# Altium schematic sheet HELPME.SchDoc
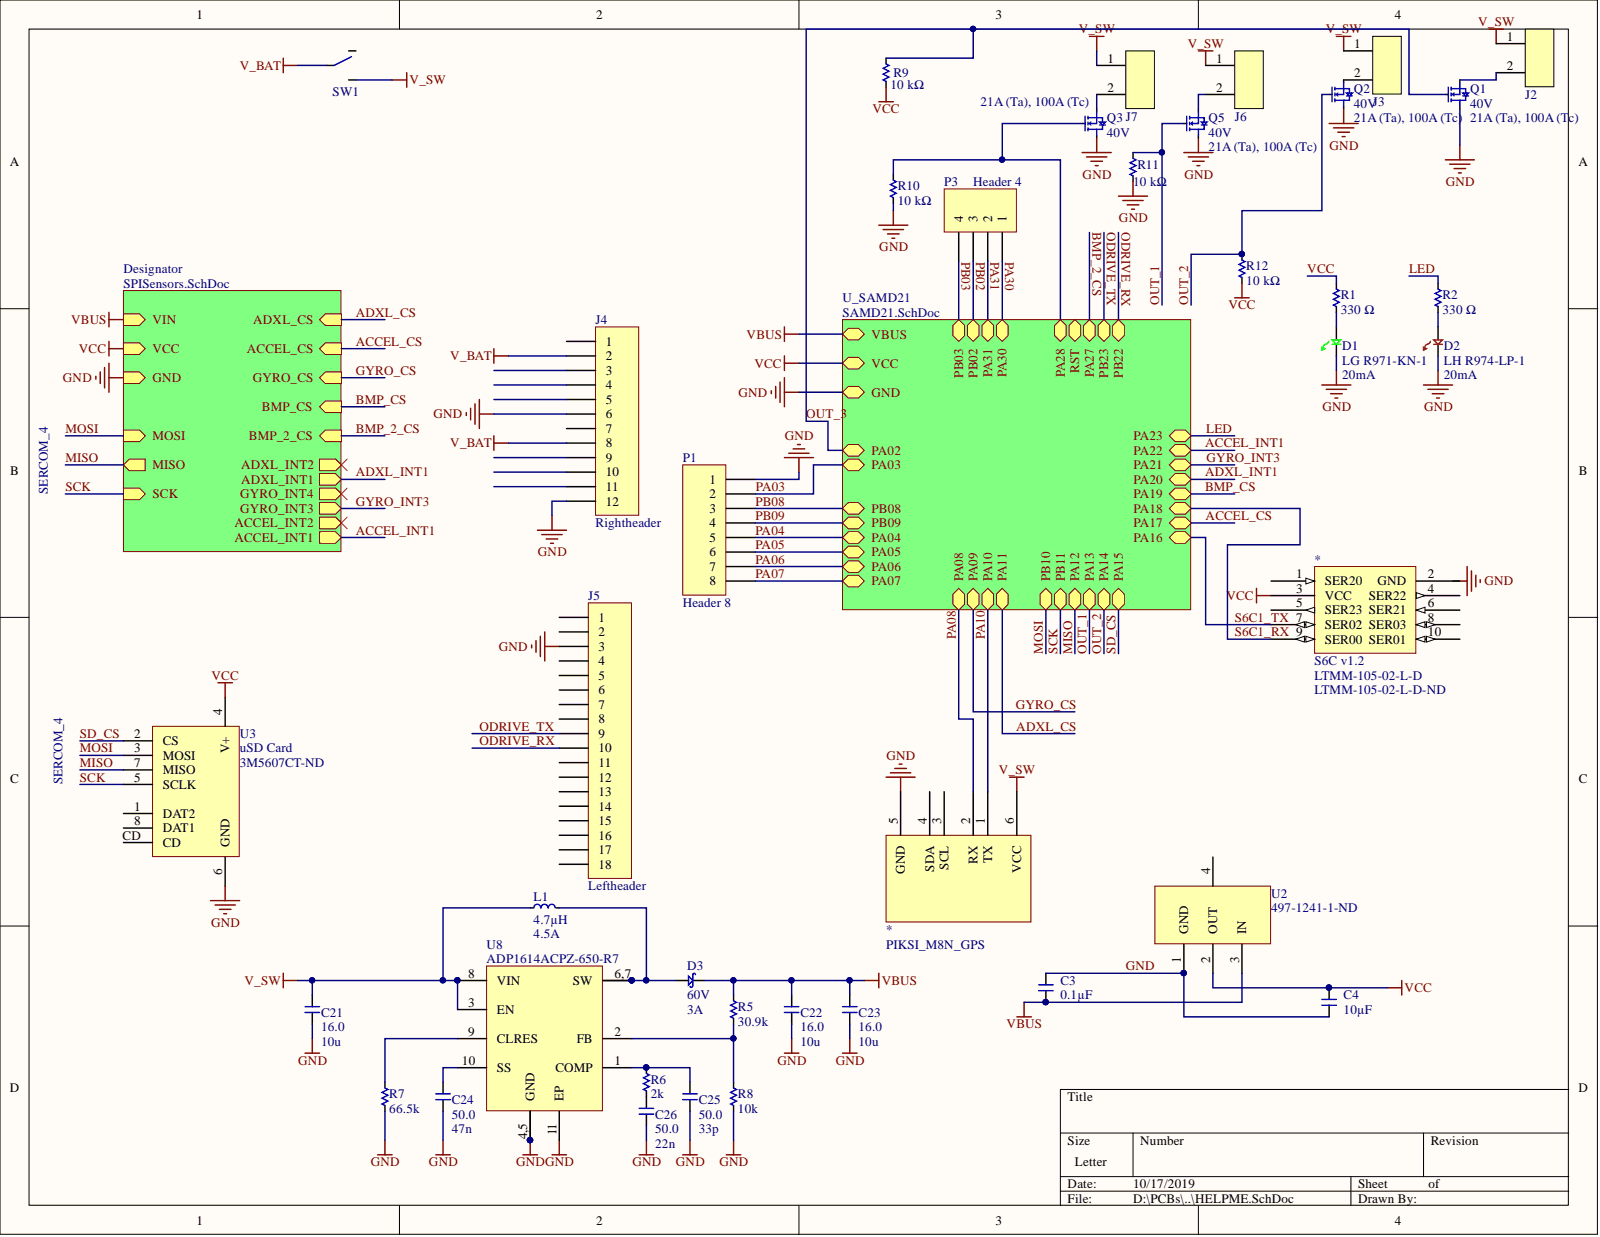
[diagram p0: HELPME.SchDoc]

## Parts
*  S6C v1.2  Supplier Part Number 1: LTMM-105-02-L-D  [S6C]
*  PIKSI_M8N_GPS  [PIKSI_M8N_GPS]
C3  Capacitance (shorthand): 0.1µF  [C0603]  (hidden comment: __template_cap)
C4  Capacitance (shorthand): 10µF  [C0603]  (hidden comment: __template_cap)
C21  human_value: 10u  voltage: 16.0  [C0805]  (hidden comment: __template_cap)
C22  human_value: 10u  voltage: 16.0  [C0805]  (hidden comment: __template_cap)
C23  human_value: 10u  voltage: 16.0  [C0805]  (hidden comment: __template_cap)
C24  voltage: 50.0  [C0805]  (hidden comment: __template_cap)
C25  human_value: 33p  voltage: 50.0  [C0805]  (hidden comment: __template_cap)
C26  human_value: 22n  voltage: 50.0  [C0805]  (hidden comment: __template_cap)
D1  Current - Test: 20mA  [0805_Diode]  (hidden comment: LED_LY971)
D2  Current - Test: 20mA  Manufacturer Part Number: LH R974-LP-1  [0805_Diode]  (hidden comment: LED_LY974)
D3  Current - Average Rectified (Io): 3A  Voltage - DC Reverse (Vr) (Max): 60V  [SMA]  (hidden comment: SCHOTTKY_B360A-13-F)
J2  CONN_BARRIER_STRIP_2POS  [TE_BARRIER_STRIP_2POS]  (value not drawn)
J3  CONN_BARRIER_STRIP_2POS  [TE_BARRIER_STRIP_2POS]  (value not drawn)
J4  Rightheader  [HDR1X12]
J5  Leftheader  [HDR1X18]
J6  CONN_BARRIER_STRIP_2POS  [TE_BARRIER_STRIP_2POS]  (value not drawn)
J7  CONN_BARRIER_STRIP_2POS  [TE_BARRIER_STRIP_2POS]  (value not drawn)
L1  Current Rating: 4.5A  Inductance: 4.7µH  [SMD5230]  (hidden comment: IND_IHLP2020CZER4R7M11)
P1  Header 8  [HDR1X8]
P3  Header 4  [HDR1X4]
Q1  Drain to Source Voltage (Vdss): 40V  [8PTDFN]  (hidden comment: NFET_BSC035N04LSG)
Q2  Drain to Source Voltage (Vdss): 40V  [8PTDFN]  (hidden comment: NFET_BSC035N04LSG)
Q3  Drain to Source Voltage (Vdss): 40V  [8PTDFN]  (hidden comment: NFET_BSC035N04LSG)
Q5  Drain to Source Voltage (Vdss): 40V  [8PTDFN]  (hidden comment: NFET_BSC035N04LSG)
R1  Resistance: 330 Ω  [R0603]  (hidden comment: __template_resistor)
R2  Resistance: 330 Ω  [R0603]  (hidden comment: __template_resistor)
R5  value 30.9k  [R0603]  (hidden comment: __template_resistor)
R6  value 2k  [R0603]  (hidden comment: __template_resistor)
R7  value 66.5k  [R0603]  (hidden comment: __template_resistor)
R8  value 10k  [R0603]  (hidden comment: __template_resistor)
R9  Resistance: 10 kΩ  [R0805]  (hidden comment: __template_resistor)
R10  Resistance: 10 kΩ  [R0805]  (hidden comment: __template_resistor)
R11  Resistance: 10 kΩ  [R0805]  (hidden comment: __template_resistor)
R12  Resistance: 10 kΩ  [R0805]  (hidden comment: __template_resistor)
SW1  SWITCH_500SSP1S1M6QEA  [SWITCH_500SSP1S1M6QEA]  (value not drawn)
U2  Supplier Part Number 1: 497-1241-1-ND  [4SOT223]  (hidden comment: LDO_LD1117S33CTR)
U3  uSD Card  Supplier Part Number 1: 3M5607CT-ND  [MICROSD_CARD_SOCKET]
U8  Manufacturer Part Number: ADP1614ACPZ-650-R7  [10DFN3X3]  (hidden comment: BOOST_ADP1614_650_R7)

## Nets
V_BAT: J4.2 J4.8 SW1.2
V_SW: *.6 C21.1 J2.1 J3.1 J6.1 J7.1 L1.1 SW1.1 U8.3(EN) U8.8(VIN)
VBUS: C3.2 C22.1 C23.1 D3.2 R5.2 U2.3(IN)
GND: *.5 C3.1 C4.2 C21.2 C22.2 C23.2 C24.2 C25.2 C26.2 D1.1 D2.1 J4.6 J4.12 J5.3 P1.1 Q1.2 Q2.2 Q3.2 Q5.2 R7.1 R8.1 R10.2 R11.2 U2.1(GND) U3.6(GND) U8.4,5(GND) U8.5 U8.11(EP)
LED: R2.2
MISO: U3.7(MISO)
MOSI: U3.3(MOSI)
ODRIVE_RX: J5.10
ODRIVE_TX: J5.9
OUT_1: Q5.1 R11.1
OUT_2: Q2.1 R12.2
OUT_3: Q1.1 R9.2
PA03: P1.2
PA04: P1.5
PA05: P1.6
PA06: P1.7
PA07: P1.8
PA08: *.2
PA10: *.1
PA30: P3.1
PA31: P3.2
PB02: P3.3
PB03: P3.4
PB08: P1.3
PB09: P1.4
S6C1_RX: *.9(SER00)
S6C1_TX: *.7(SER02)
SCK: U3.5(SCLK)
SD_CS: U3.2(CS)
VCC: *.3 C4.1 R1.2 R9.1 R12.1 U2.2(OUT) U3.4(V+)
Net*_1: *.1
Net*_2: *.2
Net*_3: *.3
Net*_4: *.4
Net*_5: *.5
Net*_6: *.6
Net*_8: *.8(SER03)
Net*_10: *.10(SER01)
NetC24_1: C24.1 U8.10(SS)
NetC25_1: C25.1 R6.2 U8.1(COMP)
NetC26_1: C26.1 R6.1
NetD1_2: D1.2 R1.1
NetD2_2: D2.2 R2.1
NetD3_1: D3.1 L1.2 U8.6,7(SW) U8.7
NetJ2_2: J2.2 Q1.3
NetJ3_2: J3.2 Q2.3
NetJ4_1: J4.1
NetJ4_3: J4.3
NetJ4_4: J4.4
NetJ4_5: J4.5
NetJ4_7: J4.7
NetJ4_9: J4.9
NetJ4_10: J4.10
NetJ4_11: J4.11
NetJ5_1: J5.1
NetJ5_2: J5.2
NetJ5_4: J5.4
NetJ5_5: J5.5
NetJ5_6: J5.6
NetJ5_7: J5.7
NetJ5_8: J5.8
NetJ5_11: J5.11
NetJ5_12: J5.12
NetJ5_13: J5.13
NetJ5_14: J5.14
NetJ5_15: J5.15
NetJ5_16: J5.16
NetJ5_17: J5.17
NetJ5_18: J5.18
NetJ6_2: J6.2 Q5.3
NetJ7_2: J7.2 Q3.3
NetQ3_1: Q3.1 R10.1
NetR5_1: R5.1 R8.2 U8.2(FB)
NetR7_2: R7.2 U8.9(CLRES)
NetSW1_3: SW1.3
NetU2_4: U2.4
NetU3_1: U3.1(DAT2)
NetU3_8: U3.8(DAT1)
NetU3_CD: U3.CD
ACCEL_CS:
ACCEL_INT1:
ACCEL_INT2:
ADXL_CS:
ADXL_INT1:
ADXL_INT2:
BMP_2_CS:
BMP_CS:
GYRO_CS:
GYRO_INT3:
GYRO_INT4:
RST:

## Library data of the parts
C3 = CAP CER 0603 0.1µF X7R 50V 10%: Manufacturer: Murata Electronics North America; Capacitance - pF (Machine readable): 100000; Database Table Name: C0603$; Dielectric: X7R; type: capacitor; Component Kind: Standard; Library Name: passives.DbLib; Signal Integrity: Guessed Model; Supplier 1: Digikey; Supplier Part Number 1: 490-1519-1-ND
C4 = CAP CER 10UF 35V X5R 0603 20%: Manufacturer: Murata Electronics North America; Capacitance - pF (Machine readable): 10000000; Database Table Name: C0603$; Dielectric: X5R; type: capacitor; Component Kind: Standard; Library Name: passives.DbLib; Signal Integrity: Guessed Model; Supplier 1: Digikey; Supplier Part Number 1: 490-13248-1-ND
C21-C23 = 587-1295-1-ND: Database Table Name: capacitor$; tolerance: 10%; type: capacitor; value: 1e-05; Component Kind: Standard; package: 0805; price: 0.315; Supplier Part Number 1: 587-1295-1-ND; Library Name: sscp.DbLib
C24 = 478-1391-1-ND: Database Table Name: capacitor$; human_value: 47n; tolerance: 10%; type: capacitor; value: 4.7e-08; Component Kind: Standard; package: 0805; price: 0.2; Supplier Part Number 1: 478-1391-1-ND; Library Name: sscp.DbLib
C25 = 399-1115-1-ND: Database Table Name: capacitor$; tolerance: 5%; type: capacitor; value: 3.3e-11; Component Kind: Standard; package: 0805; price: 0.11; Supplier Part Number 1: 399-1115-1-ND; Library Name: sscp.DbLib
C26 = 478-1387-1-ND: Database Table Name: capacitor$; tolerance: 10%; type: capacitor; value: 2.2e-08; Component Kind: Standard; package: 0805; price: 0.14; Supplier Part Number 1: 478-1387-1-ND; Library Name: sscp.DbLib
D1 = LED_LY971: LED 0805 GREEN 20MA; Manufacturer: OSRAM Opto Semiconductors Inc; Manufacturer Part Number: LG R971-KN-1; Lens Color: White; Lens Style/Size: Rectangle with Flat Top, 1.30mm x 1.25mm; Lens Transparency: Diffused; Millicandela Rating: 26.05mcd; Viewing Angle: 160°; Voltage - Forward (Vf) (Typ): 2.2V; Wavelength - Dominant: 570nm; Wavelength - Peak: 572nm; Category: Optoelectronics; Color: Green; Component Kind: Standard; ComponentLink1Description: http://www.osram-os.com/Graphics/XPic9/00078860_0.pdf; ComponentLink1URL: http://www.osram-os.com/Graphics/XPic9/00078860_0.pdf; Height (Max): 0.80mm; Mounting Type: Surface Mount; Package / Case: 0805 (2012 Metric); Packaging: Cut Tape (CT); Pricing 1: 1=0.08, 10=0.069, 100=0.0548, 250=0.04796, 500=0.04384 (USD); RoHS: RoHS Compliant; Signal Integrity: Guessed Model; Size / Dimension: 2.00mm L x 1.25mm W; Stock 1: 89733; Supplier 1: Digi-Key; Supplier Part Number 1: 475-1410-1-ND; Library Name: SSI.IntLib
D2 = LED_LY974: LED 0805 RED 20MA; Manufacturer: OSRAM Opto Semiconductors Inc; Lens Color: White; Lens Style/Size: Rectangle with Flat Top, 1.30mm x 1.25mm; Lens Transparency: Diffused; Millicandela Rating: 41mcd; Viewing Angle: 160°; Voltage - Forward (Vf) (Typ): 1.8V; Wavelength - Dominant: 645nm; Wavelength - Peak: 660nm; Category: Optoelectronics; Color: Red; Component Kind: Standard; ComponentLink1Description: http://www.osram-os.com/Graphics/XPic8/00078869_0.pdf; ComponentLink1URL: http://www.osram-os.com/Graphics/XPic8/00078869_0.pdf; Height (Max): 0.80mm; Mounting Type: Surface Mount; Package / Case: 0805 (2012 Metric); Packaging: Cut Tape (CT); Pricing 1: 1=0.08, 10=0.07, 100=0.0559, 250=0.04892, 500=0.04472 (USD); RoHS: RoHS Compliant; Signal Integrity: Guessed Model; Size / Dimension: 2.00mm L x 1.25mm W; Stock 1: 66379; Supplier 1: Digi-Key; Supplier Part Number 1: 475-1415-1-ND; Library Name: SSI.IntLib
D3 = SCHOTTKY_B360A-13-F: DIODE SCHOTTKY 40V 3A SMA; Manufacturer: Diodes Incorporated; Capacitance @ Vr, F: 200pF @ 4V, 1MHz; Current - Reverse Leakage @ Vr: 500µA @ 60V; Speed: Fast Recovery =< 500ns, > 200mA (Io); Voltage - Forward (Vf) (Max) @ If: 700mV @ 3A; Category: Discrete Semiconductor Products; Component Kind: Standard; ComponentLink1Description: http://www.diodes.com/_files/datasheets/ds30891.pdf; ComponentLink1URL: http://www.diodes.com/_files/datasheets/ds30891.pdf; Diode Type: Schottky; Manufacturer Part Number: B360A-13-F; Mounting Type: Surface Mount; Operating Temperature - Junction: -55°C ~ 150°C; Package / Case: DO-214AC, SMA; Packaging: Cut Tape (CT); Part Status: Active; Pricing 1: 1=0.45, 10=0.363 (USD); Reverse Recovery Time (trr): -; RoHS: RoHS Compliant; Stock 1: 71246; Supplier 1: Digi-Key; Supplier Device Package: SMA; Supplier Part Number 1: B360A-FDICT-ND; Library Name: SSI.IntLib
J2 J3 J6 J7 = CONN_BARRIER_STRIP_2POS: CONN BARRIER STRIP 2CIRC .375; Manufacturer: TE Connectivity; Manufacturer Part Number: 3-1437664-0; Barrier Type: 2 Wall (Dual); Bottom Termination: PC Pin; Current Rating: 20A; Material - Insulation: Polyamide (PA), Nylon; Material Flammability Rating: UL94 V-0; Number of Circuits: 2; Pitch: 0.375" (9.53mm); Top Termination: Screws with Captive Plate; Voltage Rating: 300V; Wire Gauge: 12-22 AWG; Category: Connectors, Interconnects; Color: Black; Component Kind: Standard; ComponentLink1Description: Datasheet; ComponentLink1URL: http://www.te.com/commerce/DocumentDelivery/DDEController?Action=srchrtrv&DocNm=3-1437664-0&DocType=Customer+Drawing&DocLang=English; Features: -; Mounting Type: Through Hole; Number of Rows: 1; Number of Wire Entries: 2; Operating Temperature: -40°C ~ 105°C; Packaging: Bulk; Pricing 1: 1=0.52, 10=0.483, 25=0.4348, 50=0.3864, 100=0.3703, 250=0.33812, 500=0.322, 1000=0.26565, 2500=0.2415 (USD); RoHS: RoHS Compliant; Stock 1: 24942; Supplier 1: Digi-Key; Supplier Part Number 1: A98495-ND; Terminal Block Type: Barrier Block; Terminal Finish: Zinc; Terminal Material: Steel; Library Name: SSI.IntLib
J4 = Header 12: Header, 12-Pin; Component Kind: Standard; LatestRevisionDate: 17-Jul-2002; LatestRevisionNote: Re-released for DXP Platform.; Library Name: Miscellaneous Connectors.IntLib; Signal Integrity: Connector; Publisher: Altium Limited
J5 = Header 18: Header, 18-Pin; Component Kind: Standard; LatestRevisionDate: 17-Jul-2002; LatestRevisionNote: Re-released for DXP Platform.; Library Name: Miscellaneous Connectors.IntLib; Signal Integrity: Connector; Publisher: Altium Limited
L1 = IND_IHLP2020CZER4R7M11: INDUCTOR POWER 4.7UH 4.5A SMD; Manufacturer: Vishay Dale; Current - Saturation: 5.2A; DC Resistance (DCR): 60 mOhm Max; Frequency - Test: 100kHz; Shielding: Shielded; Tolerance: ±20%; Category: Inductors, Coils, Chokes; Component Kind: Standard; ComponentLink1Description: Datasheet; ComponentLink1URL: http://www.vishay.com/docs/34260/lp20cz11.pdf; Frequency - Self Resonant: -; Manufacturer Part Number: IHLP2020CZER4R7M11; Material - Core: -; Mounting Type: Surface Mount; Operating Temperature: -55°C ~ 125°C; Package / Case: Non Standard; Packaging: Cut Tape (CT); Pricing 1: 1=1.35, 10=1.251, 25=1.1552, 50=0.9626, 100=0.9048 (USD); Q @ Freq: -; RoHS: RoHS Compliant; Size / Dimension: 0.216" L x 0.204" W x 0.118" H (5.49mm x 5.18mm x 3.00mm); Stock 1: 5793; Supplier 1: Digi-Key; Supplier Part Number 1: 541-1238-1-ND; Type: -; Library Name: SSI.IntLib
P1 = Header 8: Header, 8-Pin; Component Kind: Standard; LatestRevisionDate: 17-Jul-2002; LatestRevisionNote: Re-released for DXP Platform.; Library Name: Miscellaneous Connectors.IntLib; Signal Integrity: Connector; Publisher: Altium Limited
P3 = Header 4: Header, 4-Pin; Component Kind: Standard; LatestRevisionDate: 17-Jul-2002; LatestRevisionNote: Re-released for DXP Platform.; Library Name: Miscellaneous Connectors.IntLib; Signal Integrity: Connector; Publisher: Altium Limited
Q1-Q3 Q5 = NFET_BSC035N04LSG: MOSFET N-CH 40V 100A TDSON-8; Manufacturer: Infineon Technologies; Manufacturer Part Number: BSC035N04LSGATMA1; Current - Continuous Drain (Id) @ 25°C: 21A (Ta), 100A (Tc); FET Feature: Logic Level Gate; FET Type: MOSFET N-Channel, Metal Oxide; Gate Charge (Qg) @ Vgs: 64nC @ 10V; Input Capacitance (Ciss) @ Vds: 5100pF @ 20V; Power - Max: 69W; Rds On (Max) @ Id, Vgs: 3.5 mOhm @ 50A, 10V; Vgs(th) (Max) @ Id: 2V @ 36µA; Category: Discrete Semiconductor Products; Component Kind: Standard; ComponentLink1Description: http://www.infineon.com/dgdl/BSC035N04LSG_rev1.02.pdf?folderId=db3a3043156fd5730115c7d50620107c&fileId=db3a30431689f4420116c4388f820817; ComponentLink1URL: http://www.infineon.com/dgdl/BSC035N04LSG_rev1.02.pdf?folderId=db3a3043156fd5730115c7d50620107c&fileId=db3a30431689f4420116c4388f820817; Mounting Type: Surface Mount; Operating Temperature: -55°C ~ 150°C (TJ); Package / Case: 8-PowerTDFN; Packaging: Cut Tape (CT); Pricing 1: 1=0.95, 10=0.833, 100=0.637, 500=0.5047, 1000=0.40425 (USD); RoHS: RoHS Compliant; Stock 1: 22194; Supplier 1: Digi-Key; Supplier Device Package: PG-TDSON-8; Supplier Part Number 1: BSC035N04LSGATMA1CT-ND; Library Name: SSI.IntLib
R1 R2 = RES SMD 330 OHM 1% 1/10W 0603: Manufacturer: Yageo; Manufacturer Part Number: RC0603FR-07330RL; Database Table Name: R0603$; Power (Watts): 0.1W, 1/10W; Resistance (Sortable): 330; Temperature Coefficient: ±100ppm/°C; Tolerance: ±1%; Component Kind: Standard; Datasheets: http://www.yageo.com/documents/recent/PYu-RC_Group_51_RoHS_L_9.pdf; Image: //media.digikey.com/Renders/Yageo%20Renders/0603-(1608-Metric).jpg; Library Name: passives.DbLib; Operating Temperature: -55°C ~ 155°C; Signal Integrity: Guessed Model; Supplier 1: Digikey; Supplier Part Number 1: 311-330HRCT-ND
R5 = CRCW060330K9FKEA: RES SMD 30.9K OHM 1% 1/10W 0603; Manufacturer: Vishay Dale; Database Table Name: Resistors$; Power (Watts): 0.1W, 1/10W; Resistance (Machine readable): 30900; Unit Price (USD): 0.081; Component Kind: Standard; Library Name: resistor.DbLib; Manufacturer Part Number: CRCW060330K9FKEA; Package: 0603 (1608 Metric); Packaging: Cut Tape (CT); Series: CRCW; Supplier Part Number: 541-30.9KHCT-ND; Tolerance: ±1%; type: resistor
R6 = CRCW06032K00FKEA: RES SMD 2K OHM 1% 1/10W 0603; Manufacturer: Vishay Dale; Database Table Name: Resistors$; Power (Watts): 0.1W, 1/10W; Resistance (Machine readable): 2000; Unit Price (USD): 0.081; Component Kind: Standard; Library Name: resistor.DbLib; Manufacturer Part Number: CRCW06032K00FKEA; Package: 0603 (1608 Metric); Packaging: Cut Tape (CT); Series: CRCW; Supplier Part Number: 541-2.00KHCT-ND; Tolerance: ±1%; type: resistor
R7 = CRCW060366K5FKEA: RES SMD 66.5K OHM 1% 1/10W 0603; Manufacturer: Vishay Dale; Database Table Name: Resistors$; Power (Watts): 0.1W, 1/10W; Resistance (Machine readable): 66500; Unit Price (USD): 0.081; Component Kind: Standard; Library Name: resistor.DbLib; Manufacturer Part Number: CRCW060366K5FKEA; Package: 0603 (1608 Metric); Packaging: Cut Tape (CT); Series: CRCW; Supplier Part Number: 541-66.5KHCT-ND; Tolerance: ±1%; type: resistor
R8 = CRCW060310K0FKEA: RES SMD 10K OHM 1% 1/10W 0603; Manufacturer: Vishay Dale; Database Table Name: Resistors$; Power (Watts): 0.1W, 1/10W; Resistance (Machine readable): 10000; Unit Price (USD): 0.081; Component Kind: Standard; Library Name: resistor.DbLib; Manufacturer Part Number: CRCW060310K0FKEA; Package: 0603 (1608 Metric); Packaging: Cut Tape (CT); Series: CRCW; Supplier Part Number: 541-10.0KHCT-ND; Tolerance: ±1%; type: resistor
R9-R12 = RES SMD 10K OHM 1% 1/8W 0805: Manufacturer: Yageo; Manufacturer Part Number: RC0805FR-0710KL; Database Table Name: R0805$; Power (Watts): 0.125W, 1/8W; Resistance (Sortable): 10000; Temperature Coefficient: ±100ppm/°C; Tolerance: ±1%; Component Kind: Standard; Datasheets: http://www.yageo.com/documents/recent/PYu-RC_Group_51_RoHS_L_9.pdf; Image: //media.digikey.com/Renders/Yageo%20Renders/0805-(2012-Metric).jpg; Library Name: passives.DbLib; Operating Temperature: -55°C ~ 155°C; Supplier 1: Digikey; Supplier Part Number 1: 311-10.0KCRCT-ND
SW1 = SWITCH_500SSP1S1M6QEA: SWITCH SLIDE SPDT R/A 5A; Manufacturer: E-Switch; Actuator Length: 4.72mm; Actuator Material: Polyamide (PA), Nylon; Actuator Type: Standard; Contact Timing: Not Specified; Current Rating: 5A (AC/DC); Electrical Life: 30,000 Cycles; Switch Function: On-On; Switch Travel: 2.16mm; Voltage Rating - AC: 120V; Voltage Rating - DC: 28V; Category: Switches; Circuit: SPDT; Component Kind: Standard; ComponentLink1Description: http://spec_sheets.e-switch.com/specs/T511006.pdf; ComponentLink1URL: http://spec_sheets.e-switch.com/specs/T511006.pdf; Contact Finish: Silver; Contact Material: Copper Alloy; Features: Epoxy Sealed Terminals; Manufacturer Part Number: 500SSP1S1M6QEA; Mechanical Life: 30,000 Cycles; Mounting Type: Through Hole, Right Angle; Operating Force: -; Operating Temperature: -30°C ~ 85°C; Packaging: Bulk; Part Status: Active; Pricing 1: 1=2.93, 10=2.808, 25=2.7484, 50=2.39, 100=2.3303, 250=2.27052, 500=1.912, 1000=1.7925, 5000=1.64313 (USD); RoHS: RoHS Compliant; Stock 1: 8402; Supplier 1: Digi-Key; Supplier Part Number 1: EG2478-ND; Termination Style: PC Pin; Library Name: SSI.IntLib
U2 = LDO_LD1117S33CTR: IC VOLTAGE REG 3.3V 800MA SOT223 INDUSTRIAL TEMP; Manufacturer: STMicroelectronics; Number of Regulators: 1; Regulator Topology: Positive Fixed; Voltage - Dropout (Typical): 1.1V @ 800mA; Voltage - Input: Up to 15V; Category: Integrated Circuits (ICs); Component Kind: Standard; ComponentLink1Description: http://www.st.com/content/ccc/resource/technical/document/datasheet/99/3b/7d/91/91/51/4b/be/CD00000544.pdf/files/CD00000544.pdf/jcr:content/translations/en.CD00000544.pdf; ComponentLink1URL: http://www.st.com/content/ccc/resource/technical/document/datasheet/99/3b/7d/91/91/51/4b/be/CD00000544.pdf/files/CD00000544.pdf/jcr:content/translations/en.CD00000544.pdf; Current - Limit (Min): -; Current - Output: 800mA; Manufacturer Part Number: LD1117S33CTR; Mounting Type: Surface Mount; Operating Temperature: -40°C ~ 125°C; Package / Case: TO-261-4, TO-261AA; Packaging: Cut Tape (CT); Part Status: Active; Pricing 1: 1=0.46, 10=0.373, 100=0.2538, 500=0.19034, 1000=0.14276 (USD); RoHS: RoHS Compliant; Stock 1: 63873; Supplier 1: Digi-Key; Supplier Device Package: SOT-223; Voltage - Output: 3.3V; Library Name: SSI.IntLib
U3 = MICRO_SD_CARD_HOLDER: MICROSD CARD HOLDER, PUSH-PUSH; Manufacturer: 3M; Manufacturer Part Number: 2908-05WB-MG; Card Type: Secure Digital - microSD™; Connector Type: Connector and Ejector; Insertion, Removal Method: Push In, Push Out; Number of Positions: 10 (8 + 2); Category: Connectors, Interconnects; Component Kind: Standard; ComponentLink1Description: http://multimedia.3m.com/mws/media/416257O/3mtm-card-connector-microsdtm-2900-series-ts2197.pdf; ComponentLink1URL: http://multimedia.3m.com/mws/media/416257O/3mtm-card-connector-microsdtm-2900-series-ts2197.pdf; Contact Finish: Gold; Contact Finish Thickness: 15µin (0.38µm); Ejector Side: -; Features: Switch; Height Above Board: 0.073" (1.85mm); Mounting Feature: Normal, Standard - Top; Mounting Type: Surface Mount, Right Angle; Packaging: Cut Tape (CT); Pricing 1: 1=3.6, 10=3.458, 25=3.1704, 50=3.0262, 100=2.882, 250=2.52176, 500=2.4497, 1000=2.08945 (USD); RoHS: RoHS Compliant; Stock 1: 32313; Supplier 1: Digi-Key; Library Name: SSI.IntLib
U8 = BOOST_ADP1614_650_R7: IC BOOST ADJ 4A 10DFN 650kHZ; Manufacturer: Analog Devices Inc.; Current - Output: 4A (Switch); Function: Step-Up; Number of Outputs: 1; Output Configuration: Positive; Output Type: Adjustable; Synchronous Rectifier: No; Voltage - Input (Max): 5.5V; Voltage - Input (Min): 2.5V; Voltage - Output (Max): 20V; Category: Integrated Circuits (ICs); Component Kind: Standard; ComponentLink1Description: http://www.analog.com/media/en/technical-documentation/data-sheets/ADP1614.pdf; ComponentLink1URL: http://www.analog.com/media/en/technical-documentation/data-sheets/ADP1614.pdf; Frequency - Switching: 650kHz; Mounting Type: Surface Mount; Operating Temperature: -40°C ~ 125°C (TJ); Package / Case: 10-WFDFN Exposed Pad, CSP; Packaging: Cut Tape (CT); Part Status: Active; Pricing 1: 1=2.81, 10=2.528, 100=2.0321, 500=1.66956 (USD); RoHS: RoHS Compliant; Stock 1: 513; Supplier 1: Digi-Key; Supplier Device Package: 10-LFCSP-WD (3x3); Supplier Part Number 1: ADP1614ACPZ-650-R7CT-ND; Topology: Boost; Voltage - Output (Min/Fixed): 2.5V; Library Name: SSI.IntLib
* = S6C v1.2: Component Kind: Standard; Supplier 1: Samtec; Supplier 2: Digi-Key; Supplier Part Number 2: LTMM-105-02-L-D-ND; Library Name: SSI.IntLib
* = PIKSI_M8N_GPS: Component Kind: Standard; Library Name: SSI.IntLib
